# Altium schematic sheet TF_Card.SchDoc(TF_Card)
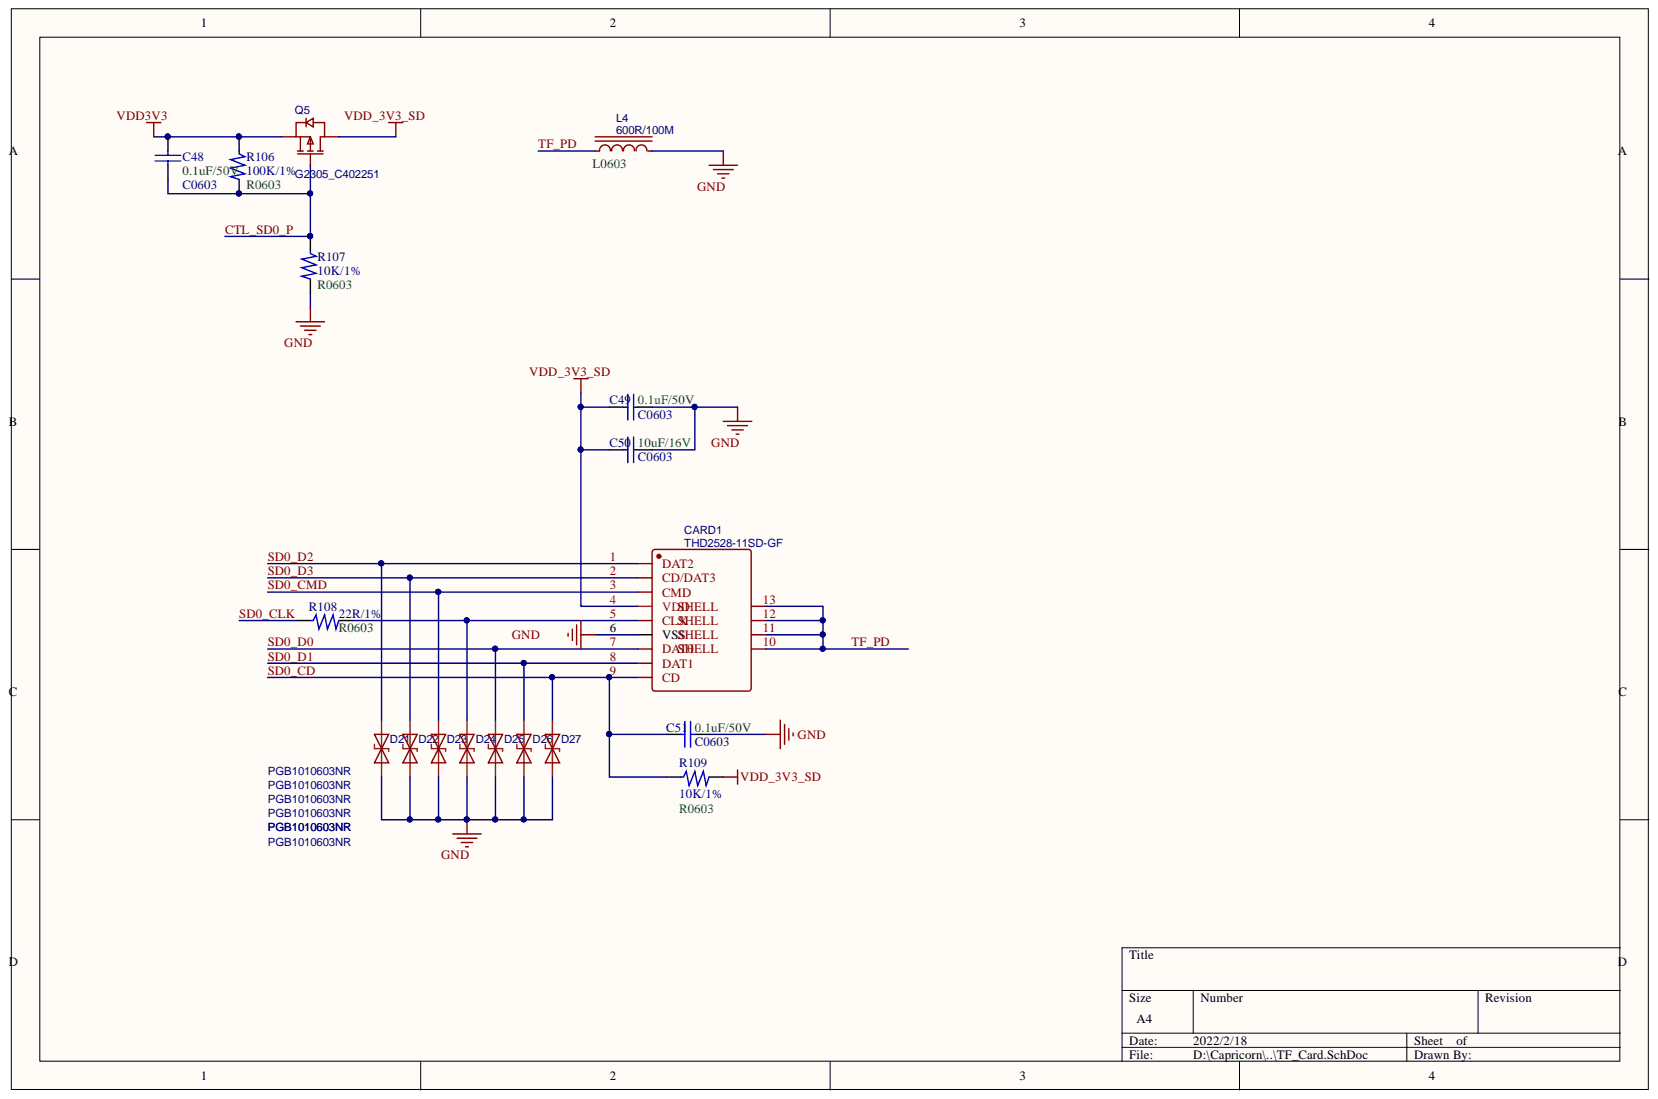
[diagram p0: TF_Card.SchDoc]

## Parts
C48  0.1uF/50V  value C0603  [0603_C]
C49  0.1uF/50V  value C0603  [0603_C]
C50  10uF/16V  value C0603  [0603_C]
C51  0.1uF/50V  value C0603  [0603_C]
CARD1  THD2528-11SD-GF  [TF-SMD_THD2528-11SD-GF]
D21  PGB1010603NR  [D0603-BI]
D22  PGB1010603NR  [D0603-BI]
D23  PGB1010603NR  [D0603-BI]
D24  PGB1010603NR  [D0603-BI]
D25  PGB1010603NR  [D0603-BI]
D26  PGB1010603NR  [D0603-BI]
D27  PGB1010603NR  [D0603-BI]
L4  600R/100M  [0603_L]
Q5  G2305_C402251  [SOT-23-3L]
R106  100K/1%  [R0603]
R107  10K/1%  [R0603]
R108  22R/1%  [R0603]
R109  10K/1%  [R0603]

## Nets
GND: C49.2 C50.2 C51.1 CARD1.6 D21.1 D22.1 D23.1 D24.1 D25.1 D26.1 D27.1 L4.2 R107.1
VDD3V3: C48.1 Q5.2 R106.2
VDD_3V3_SD: C49.1 C50.1 CARD1.4(VDD) Q5.3 R109.1
CTL_SD0_P: C48.2 Q5.1 R106.1 R107.2
SD0_CD: C51.2 CARD1.9(CD) D27.2 R109.2
SD0_CLK: R108.2
SD0_CMD: CARD1.3(CMD) D23.2
SD0_D0: CARD1.7(DAT0) D25.2
SD0_D1: CARD1.8(DAT1) D26.2
SD0_D2: CARD1.1(DAT2) D21.2
SD0_D3: CARD1.2(CD/DAT3) D22.2
TF_PD: CARD1.10 CARD1.11 CARD1.12 CARD1.13 L4.1
NetCARD1_5: CARD1.5(CLX) D24.2 R108.1

## Library data of the parts
C48-C51 = CAP: Capacitor; Component Kind: Standard
CARD1 = THD2528-11SD-GF: BOM_Manufacturer: THD; Contributor: LCSC; BOM_JLCPCB Part Class: Extended Part; BOM_Manufacturer Part: THD2528-11SD-GF; BOM_Supplier: LCSC; BOM_Supplier Part: C283232; Component Kind: Standard; spicePre: C; spiceSymbolName: THD2528-11SD-GF
D21-D27 = PGB1010603NR: BOM_Manufacturer: Littelfuse; BOM_JLCPCB Part Class: Extended Part; BOM_Manufacturer Part: PGB1010603NR; BOM_Supplier: LCSC; BOM_Supplier Part: C10677; Component Kind: Standard; spicePre: D; spiceSymbolName: PGB1010603NR
L4 = SCBM160808U600T: BOM_Manufacturer: Yanchuang; Contributor: LCSC; : L0603; BOM_Manufacturer Part: SCBM160808U600T; BOM_Supplier: LCSC; BOM_Supplier Part: C494701; Component Kind: Standard; spicePre: L; spiceSymbolName: SCBM160808U600T
Q5 = G2305_C402251: BOM_Manufacturer: LGE; BOM_Manufacturer Part: G2305; Contributor: LCSC; BOM_JLCPCB Part Class: Extended Part; BOM_Supplier: LCSC; BOM_Supplier Part: C402251; Component Kind: Standard; spicePre: Q; spiceSymbolName: G2305_C402251
R106-R109 = 电阻: Component Kind: Standard
